# Altium schematic sheet Power.SchDoc
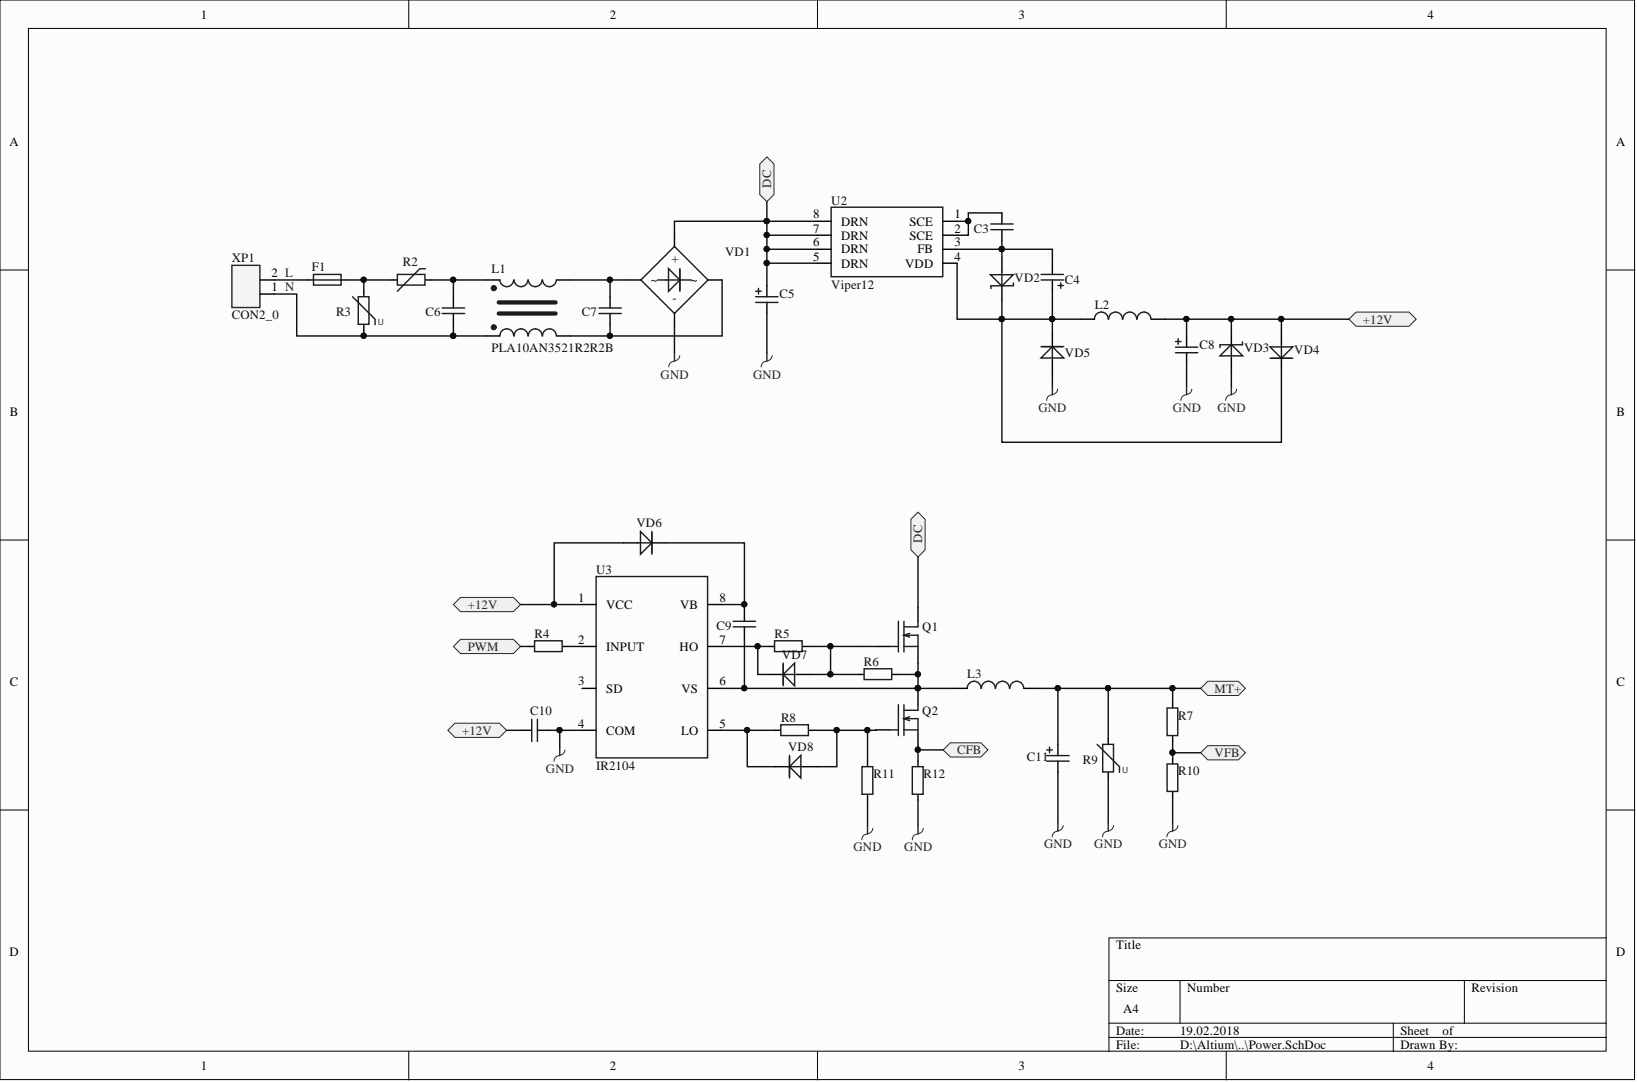
[diagram p0: Power.SchDoc]

## Parts
C3  (no value)  [SMD_0805]
C4  (no value)  [CAPR-5/2.5]
C5  (no value)  [CAPR-5/2.5]
C6  (no value)  [SMD_0805]
C7  (no value)  [SMD_0805]
C8  (no value)  [CAPR-5/2.5]
C9  (no value)  [SMD_0805]
C10  (no value)  [SMD_0805]
C11  (no value)  [CAPR-5/2.5]
F1  (no value)
L1  PLA10AN3521R2R2B  [PLA10AN3521R2R2B]
L2  (no value)
L3  (no value)
Q1  (no value)  [MOSFET-DPAK-GDS]
Q2  (no value)  [MOSFET-DPAK-GDS]
R2  (no value)
R3  (no value)
R4  (no value)  [SMD_0805]
R5  (no value)  [SMD_0805]
R6  (no value)  [SMD_0805]
R7  (no value)  [SMD_0805]
R8  (no value)  [SMD_0805]
R9  (no value)
R10  (no value)  [SMD_0805]
R11  (no value)  [SMD_0805]
R12  (no value)  [SMD_0805]
U2  Viper12  [SO8-150mil]
U3  IR2104  [SO8-150mil]
VD1  DIODE BRIDGE  [GBU BRIDGE]  (value not drawn)
VD2  (no value)  [LED-3mm]
VD3  (no value)  [LED-3mm]
VD4  (no value)  [DO214-AC]
VD5  (no value)  [DO214-AC]
VD6  (no value)  [DO214-AC]
VD7  (no value)  [DO214-AC]
VD8  (no value)  [DO214-AC]
XP1  CON2_0  [HEAD-1/2/2.54]

## Ports
+12V, CFB, DC, MT+, PWM, VFB

## Nets
GND: C5.2 C8.2 C10.2 C11.2 R9.1 R10.1 R11.2 R12.2 U3.4(COM) VD1.4(-) VD3.2 VD5.2
+12V: C8.1 C10.1 L2.2 U3.1(VCC) VD3.1 VD4.2 VD6.2 ; port +12V
CFB: Q2.3 R12.1 ; port CFB
DC: C5.1 Q1.2 U2.5(DRN) U2.6(DRN) U2.7(DRN) U2.8(DRN) VD1.1(+) ; port DC
L: F1.2 XP1.2
MT+: C11.1 L3.2 R7.2 R9.2 ; port MT+
N: C6.2 L1.1 R3.1 XP1.1
PWM: R4.1 ; port PWM
VFB: R7.1 R10.2 ; port VFB
NetC3_1: C3.1 U2.1(SCE) U2.2(SCE)
NetC3_2: C3.2 C4.2 U2.3(FB) VD2.2
NetC4_1: C4.1 L2.1 U2.4(VDD) VD2.1 VD4.1 VD5.1
NetC6_1: C6.1 L1.4 R2.1
NetC7_1: C7.1 L1.3 VD1.3(~)
NetC7_2: C7.2 L1.2 VD1.2(~)
NetC9_1: C9.1 U3.8(VB) VD6.1
NetC9_2: C9.2 U3.6(VS)
NetF1_1: F1.1 R2.2 R3.2
NetL3_1: L3.1 Q1.3 Q2.2 R6.2
NetQ2_1: Q2.1 R8.1 R11.1 VD8.2
NetR4_2: R4.2 U3.2(INPUT)
NetR5_1: R5.1 R6.1 VD7.2
NetR5_2: R5.2 U3.7(HO) VD7.1
NetR8_2: R8.2 U3.5(LO) VD8.1

Not connected: Q1 1; U3 3(SD)

## Library data of the parts
C3 C6 C7 C9 C10 = C_NONE_POLAR: None polar capacitor; Component Kind: Standard; Library Name: DISCRETE.SchLib
C4 C5 C8 C11 = C_POLAR: Polar capacitor; Component Kind: Standard; Library Name: DISCRETE.SchLib
F1 = FUSE: Resistor; Component Kind: Standard; Library Name: DISCRETE.SchLib
L1 = Inductor Sin: Component Kind: Standard; Library Name: DISCRETE.SchLib
L2 L3 = INDUCTOR: Component Kind: Standard; Library Name: DISCRETE.SchLib
Q1 Q2 = IG-FET N_0: Component Kind: Standard; Library Name: DISCRETE.SchLib
R2 = RES NTC: Component Kind: Standard; Library Name: DISCRETE.SchLib
R3 R9 = RES VARISTOR: Component Kind: Standard; Library Name: DISCRETE.SchLib
R4-R8 R10-R12 = RES: Resistor; Component Kind: Standard; Library Name: DISCRETE.SchLib
VD2 VD3 = ZENER: Zener diode; Component Kind: Standard; Library Name: DISCRETE.SchLib
VD4-VD8 = DIODE: Component Kind: Standard; Description: Diode; Library Name: DISCRETE.SchLib
U2 = Viper12: Component Kind: Standard; Library Name: ICs.SchLib
U3 = IR2104: Component Kind: Standard; Library Name: ICs.SchLib
VD1 = DIODE BRIDGE: Component Kind: Standard; Library Name: DISCRETE.SchLib
XP1 = CON2_0: Component Kind: Standard; Library Name: Connectors.SchLib
